# Altium schematic sheet MCU.SchDoc(MCU)
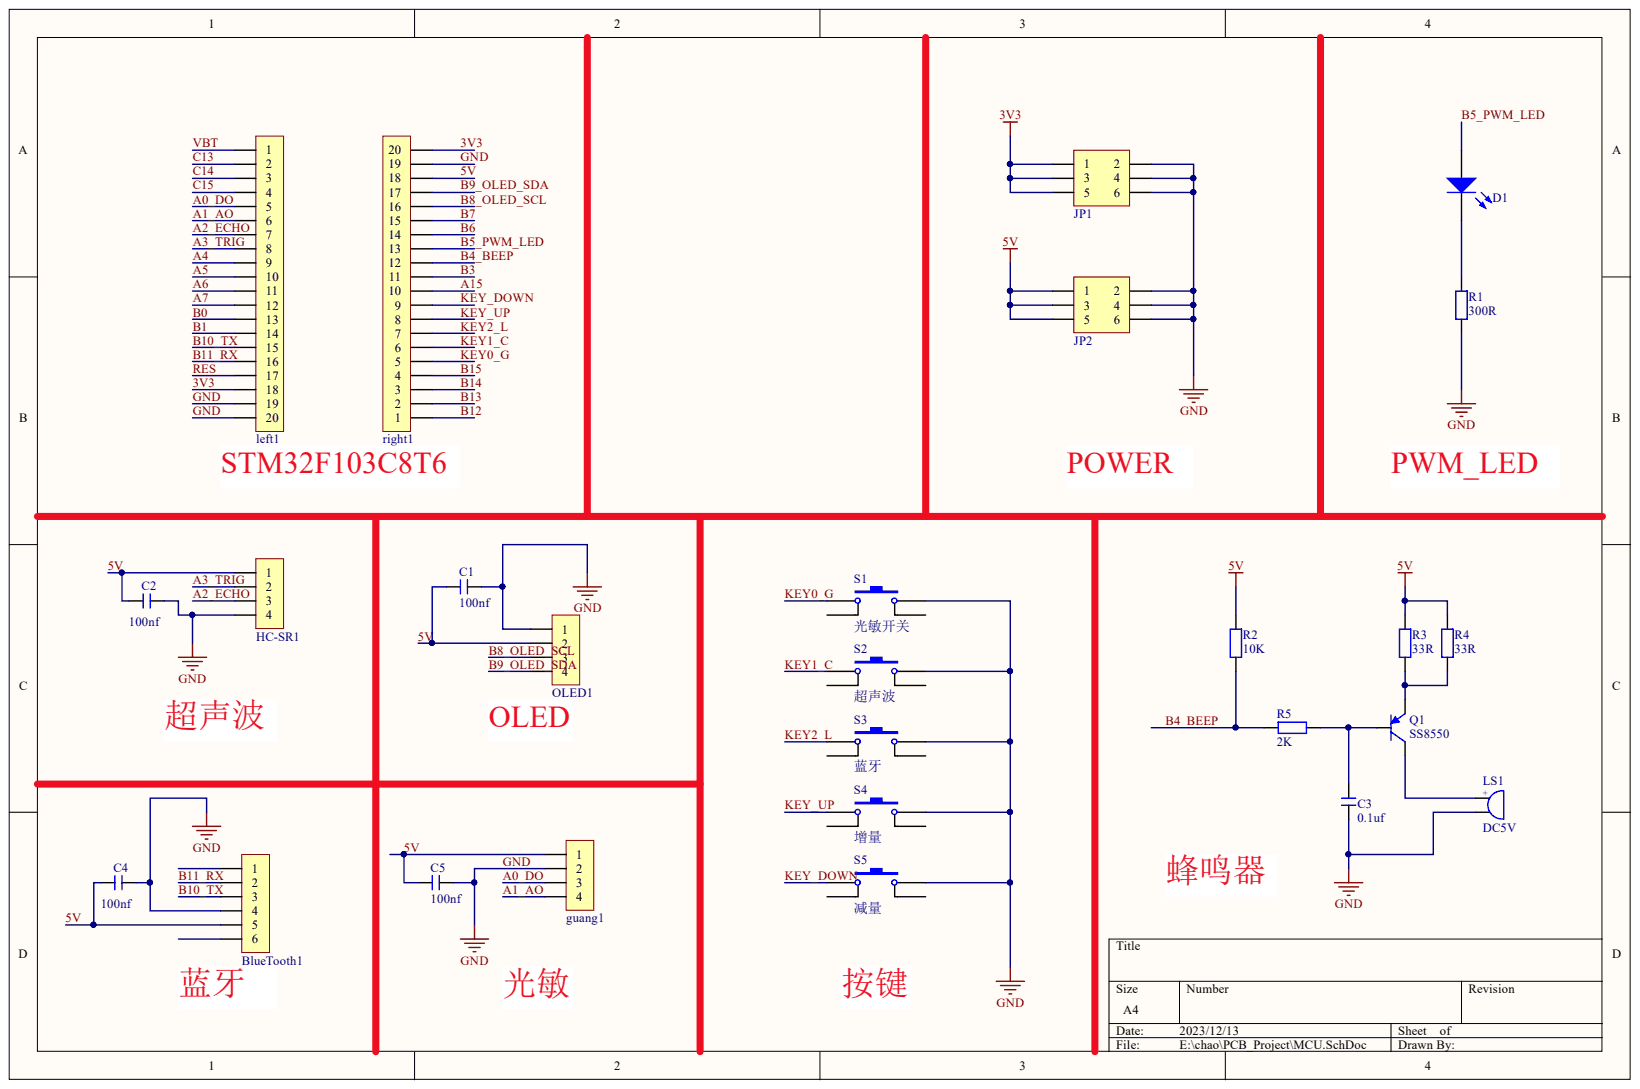
[diagram p0: MCU.SchDoc]

## Parts
BlueTooth1  HDR-1X6  [HDR2.54-LI-6P]  (value not drawn)
C1  100nf  [C 0805_L]
C2  100nf  [C 0805_L]
C3  0.1uf  [C 0805_L]
C4  100nf  [C 0805_L]
C5  100nf  [C 0805_L]
D1  LED  [LED_A2]  (value not drawn)
guang1  HDR-1X4  [HDR2.54-LI-4P]  (value not drawn)
HC-SR1  HDR-1X4  [HDR2.54-LI-4P]  (value not drawn)
JP1  Header3X2  [HDR2.54-LI-2x3P]  (value not drawn)
JP2  Header3X2  [HDR2.54-LI-2x3P]  (value not drawn)
left1  HDR-1X20  [HDR2.54-LI-20P]  (value not drawn)
LS1  DC5V  [BEEP 5x9x5.5]
OLED1  HDR-1X4  [HDR2.54-LI-4P]  (value not drawn)
Q1  SS8550  [TO92A]
R1  300R  [R 0805_L]
R2  10K  [R 0805_L]
R3  33R  [R 0805_L]
R4  33R  [R 0805_L]
R5  2K  [R 0805_L]
right1  HDR-1X20  [HDR2.54-LI-20P]  (value not drawn)
S1  光敏开关  [SW_PB4_A1]
S2  超声波  [SW_PB4_A1]
S3  蓝牙  [SW_PB4_A1]
S4  增量  [SW_PB4_A1]
S5  减量  [SW_PB4_A1]

## Nets
3V3: JP1.1 JP1.3 JP1.5 left1.18 right1.20
5V: BlueTooth1.5 C1.2 C2.1 C4.1 C5.2 guang1.1 HC-SR1.1 JP2.1 JP2.3 JP2.5 OLED1.2 R2.2 R3.2 R4.2 right1.18
A0_DO: guang1.3 left1.5
A1_AO: guang1.4 left1.6
A2_ECHO: HC-SR1.3 left1.7
A3_TRIG: HC-SR1.2 left1.8
A4: left1.9
A5: left1.10
A6: left1.11
A7: left1.12
A15: right1.10
B0: left1.13
B1: left1.14
B3: right1.11
B4_BEEP: R2.1 R5.2 right1.12
B5_PWM_LED: D1.1 right1.13
B6: right1.14
B7: right1.15
B8_OLED_SCL: OLED1.3 right1.16
B9_OLED_SDA: OLED1.4 right1.17
B10_TX: BlueTooth1.3 left1.15
B11_RX: BlueTooth1.2 left1.16
B12: right1.1
B13: right1.2
B14: right1.3
B15: right1.4
C13: left1.2
C14: left1.3
C15: left1.4
GND: BlueTooth1.4 C1.1 C2.2 C3.1 C4.2 C5.1 guang1.2 HC-SR1.4 JP1.2 JP1.4 JP1.6 JP2.2 JP2.4 JP2.6 left1.19 left1.20 LS1.2 OLED1.1 R1.1 right1.19 S1.2 S2.2 S3.2 S4.2 S5.2
KEY0_G: right1.5 S1.1
KEY1_C: right1.6 S2.1
KEY2_L: right1.7 S3.1
KEY_DOWN: right1.9 S5.1
KEY_UP: right1.8 S4.1
RES: left1.17
VBT: left1.1
NetC3_2: C3.2 Q1.2 R5.1
NetD1_2: D1.2 R1.2
NetLS1_1: LS1.1 Q1.3
NetQ1_1: Q1.1 R3.1 R4.1

Not connected: BlueTooth1 1 6; S1 3 4; S2 3 4; S3 3 4; S4 3 4; S5 3 4

## Library data of the parts
BlueTooth1 = Header 6: 6P接插件; 版权归属: 封装库来自淘宝 ID：黄晶宝石; BOM名称: 6P接插件; Component Kind: Standard; Library Name: 5.4 - 接插件-脚距2.54 (100mil) .SchLib; 学习资源: https://shop463601444.taobao.com
C1-C5 = C: 贴片电容; BOM名称: 无极性贴片电容; 淘宝ID: 封装库来自淘宝 ID：黄晶宝石; Component Kind: Standard; Library Name: 0.1 - 电阻-电容-电感.SchLib; 唯一出售店铺网址: https://shop463601444.taobao.com; 联系售后，技术支持: https://shop463601444.taobao.com
D1 = LED: 发光二极管; Component Kind: Standard; Library Name: 0.3 - LED发光二极管.SchLib; 技术支持，联系售后: https://shop463601444.taobao.com
guang1 HC-SR1 OLED1 = Header 4: 4P接插件; 版权归属: 封装库来自淘宝 ID：黄晶宝石; BOM名称: 4P接插件; Component Kind: Standard; Library Name: 5.4 - 接插件-脚距2.54 (100mil) .SchLib; 学习资源: https://shop463601444.taobao.com
JP1 JP2 = Header 3X2: 3*2P接插件; 版权归属: 封装库来自淘宝 ID：黄晶宝石; BOM名称: 3*2P接插件; Component Kind: Standard; Library Name: 5.4 - 接插件-脚距2.54 (100mil) .SchLib; 学习资源: https://shop463601444.taobao.com
left1 right1 = Header 20: 20P接插件; 版权归属: 封装库来自淘宝 ID：黄晶宝石; BOM名称: 20P接插件; Component Kind: Standard; Library Name: 5.4 - 接插件-脚距2.54 (100mil) .SchLib; 学习资源: https://shop463601444.taobao.com
LS1 = BEEP: 蜂鸣器; 版权归属: 封装库来自淘宝 ID：黄晶宝石; BOM名称: 蜂鸣器; Component Kind: Standard; Library Name: 4.1 - 未分类器件.SchLib; 技术支持，联系售后: https://shop463601444.taobao.com
Q1 = 8550-DIP: 高频放大-PNP型; 属性: 40V 1.5A 1W 100MHZ; 版权归属: 封装库来自淘宝 ID：黄晶宝石; BOM名称: 高频放大-PNP型; Component Kind: Standard; Library Name: 0.4 - 三极管.SchLib; 技术支持，联系售后: https://shop463601444.taobao.com
R1-R5 = R: 贴片电阻; 封装库来自淘宝卖家 ID：黄晶宝石: 其他店铺购入均为盗版，不享受售后技术支持服务，请立即申请退款; 版权归属: 来自淘宝 ID：黄晶宝石; BOM名称: 贴片电阻; Component Kind: Standard; Library Name: 0.1 - 电阻-电容-电感.SchLib; 联系售后: https://shop463601444.taobao.com
S1-S5 = SW-PB4: 微动开关; Component Kind: Standard; LatestRevisionDate: 17-Jul-2002; LatestRevisionNote: Re-released for DXP Platform.; Library Name: 0.7 - 常用按键-开关.SchLib; PackageReference: SPST-2; Published: 24-Mar-1999; Publisher: Altium Limited
